# Altium schematic sheet 核心板.SchDoc
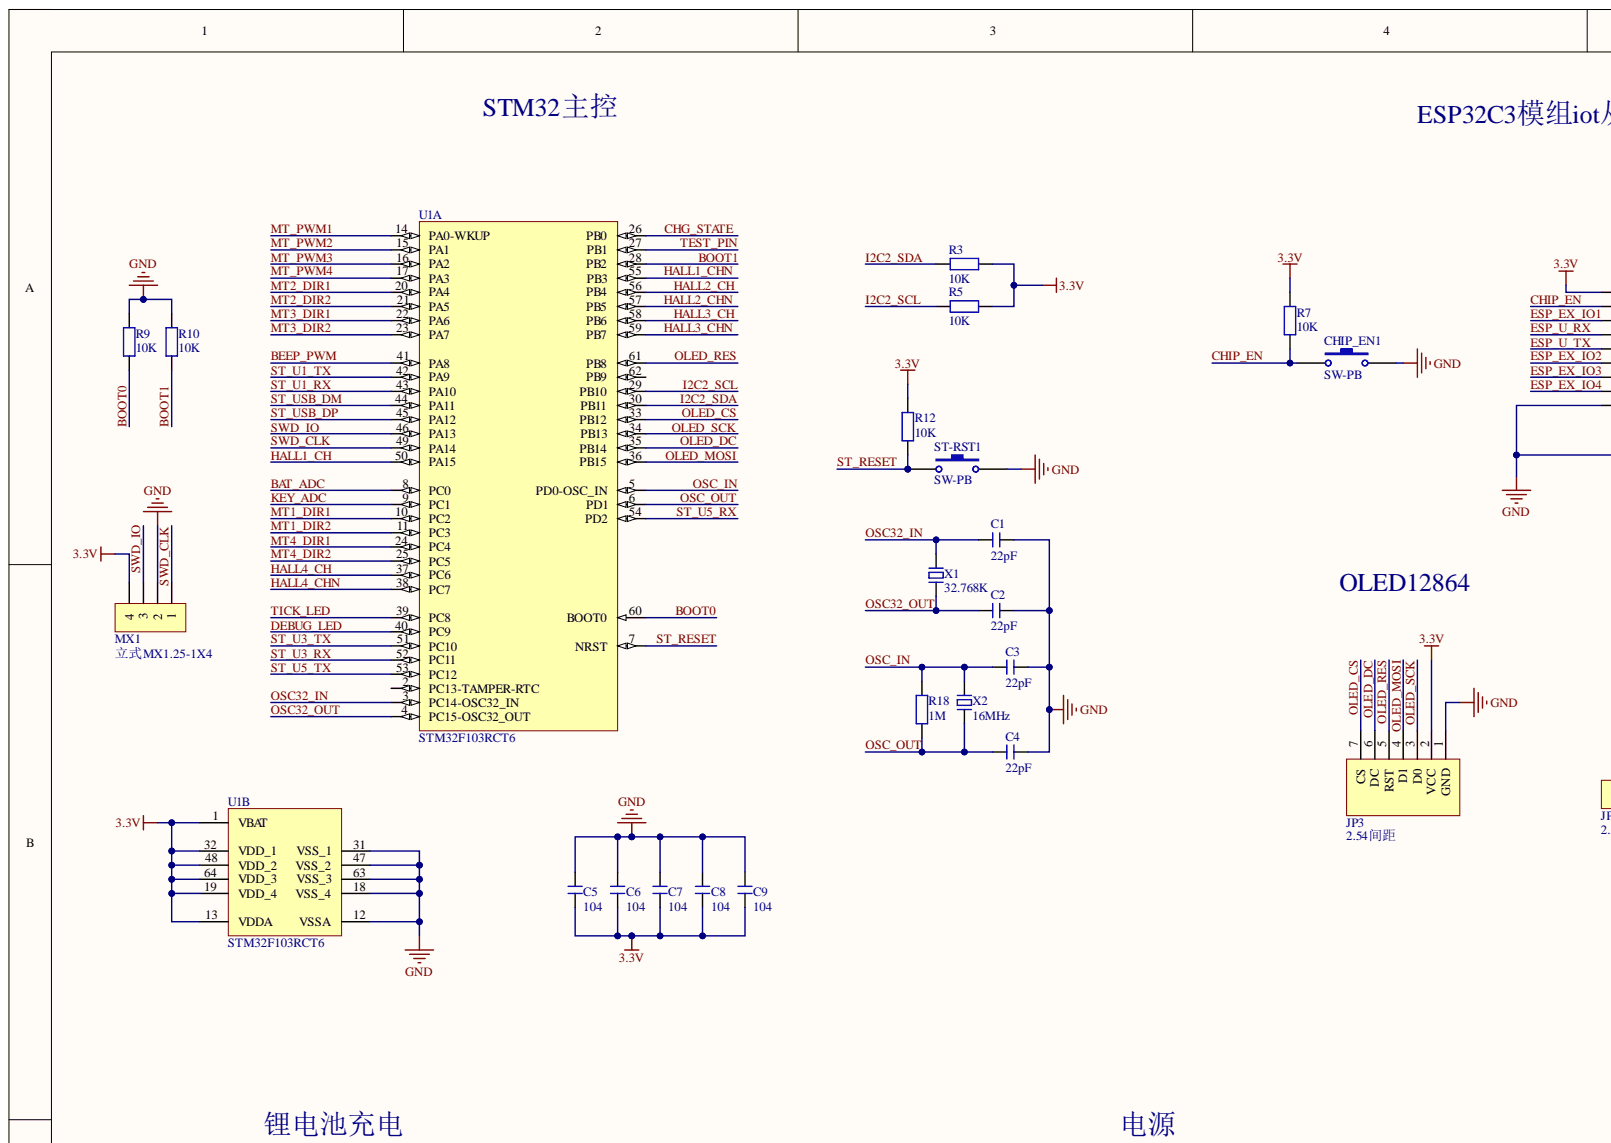
[diagram p0: 核心板.SchDoc, top left]
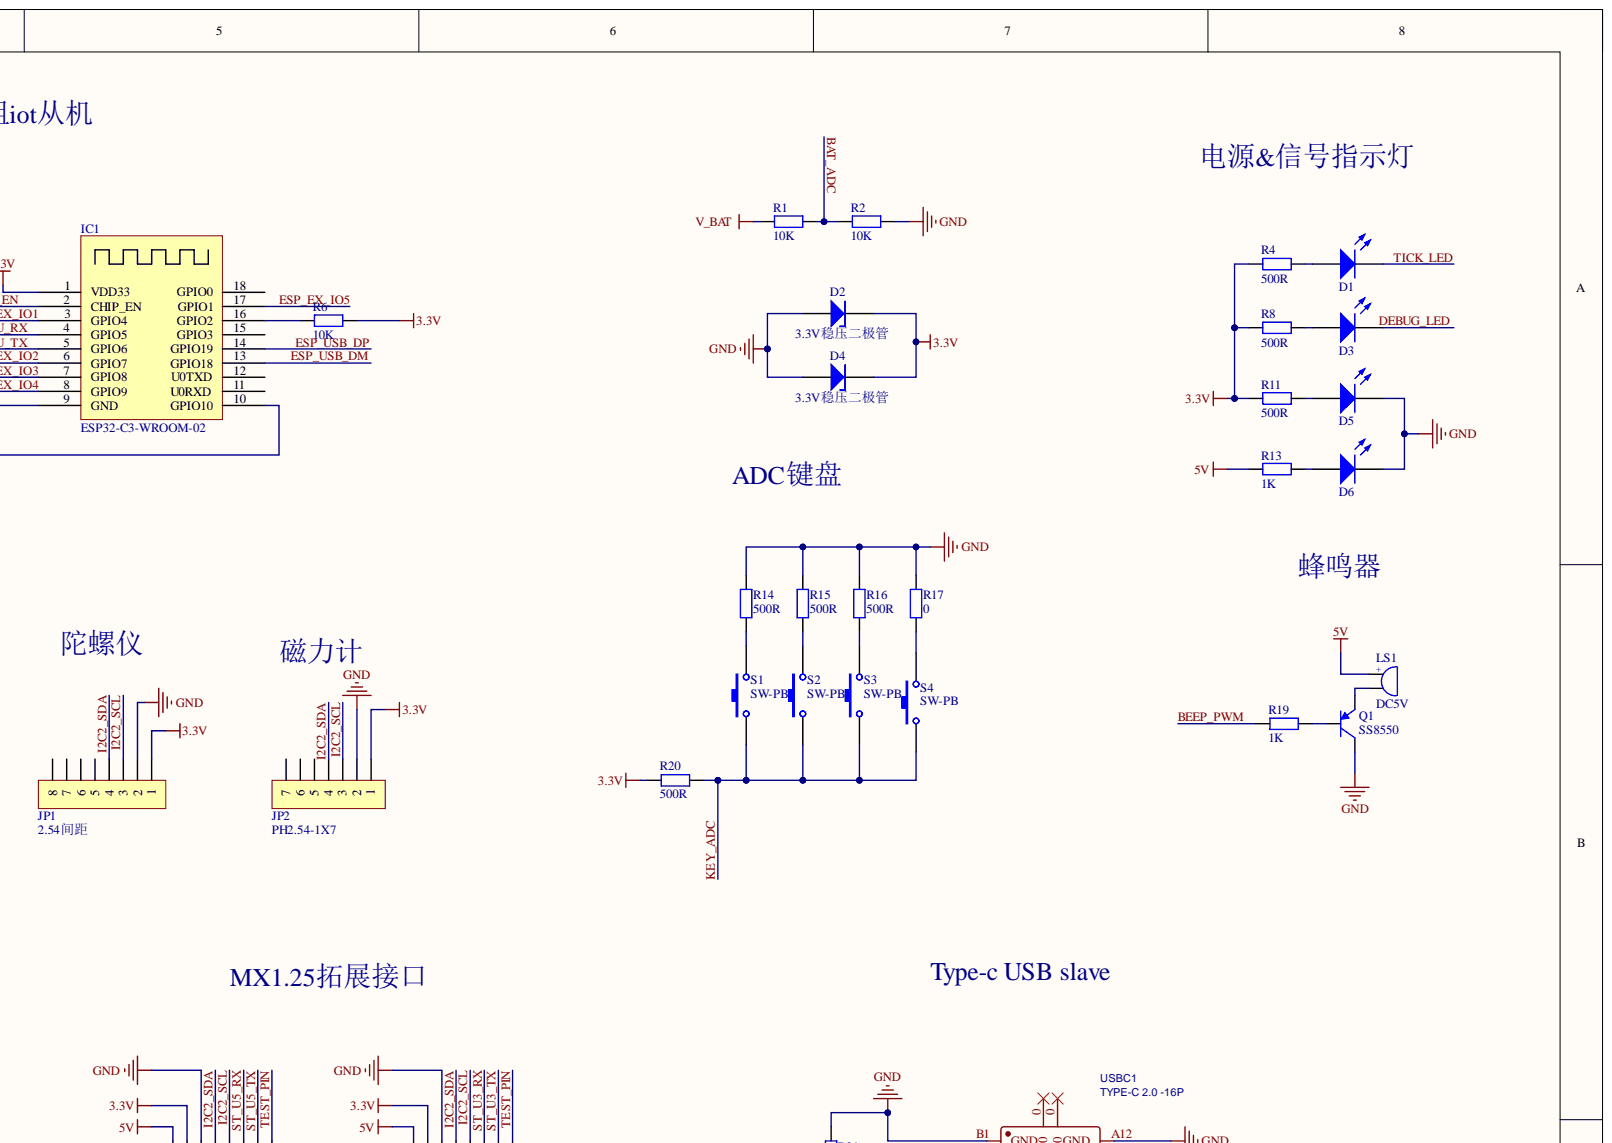
[diagram p1: 核心板.SchDoc, top right]
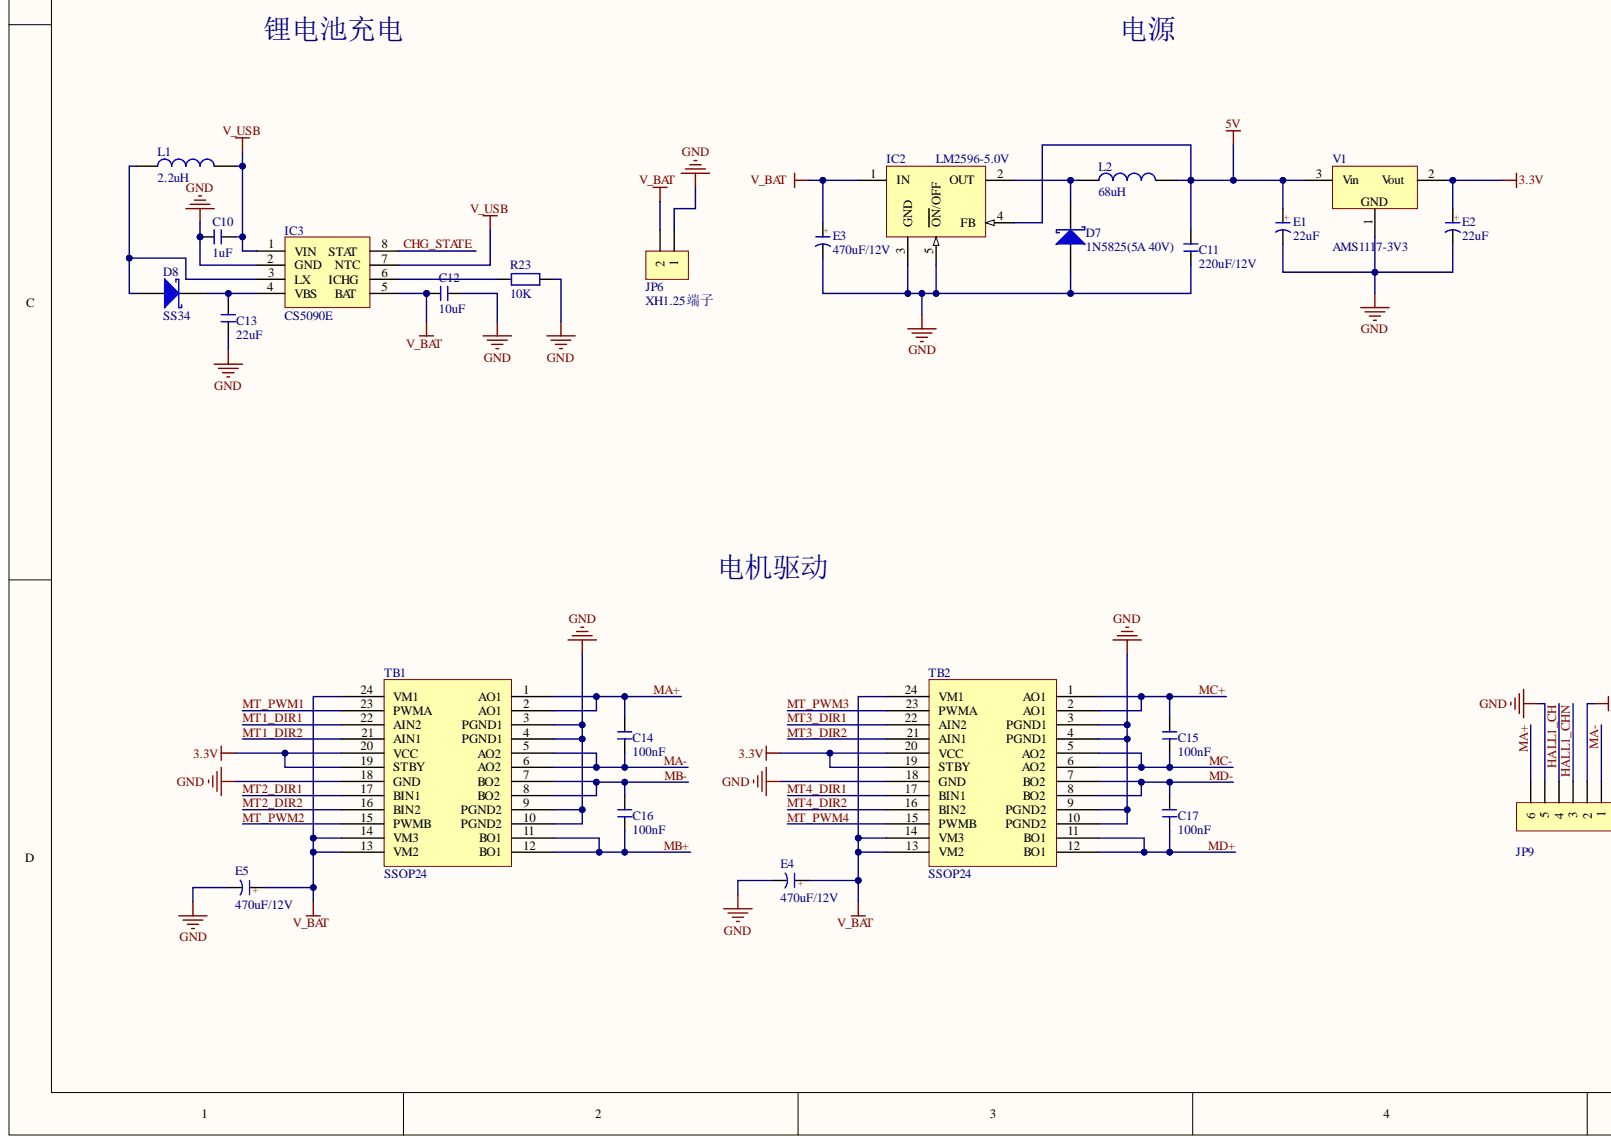
[diagram p2: 核心板.SchDoc, bottom left]
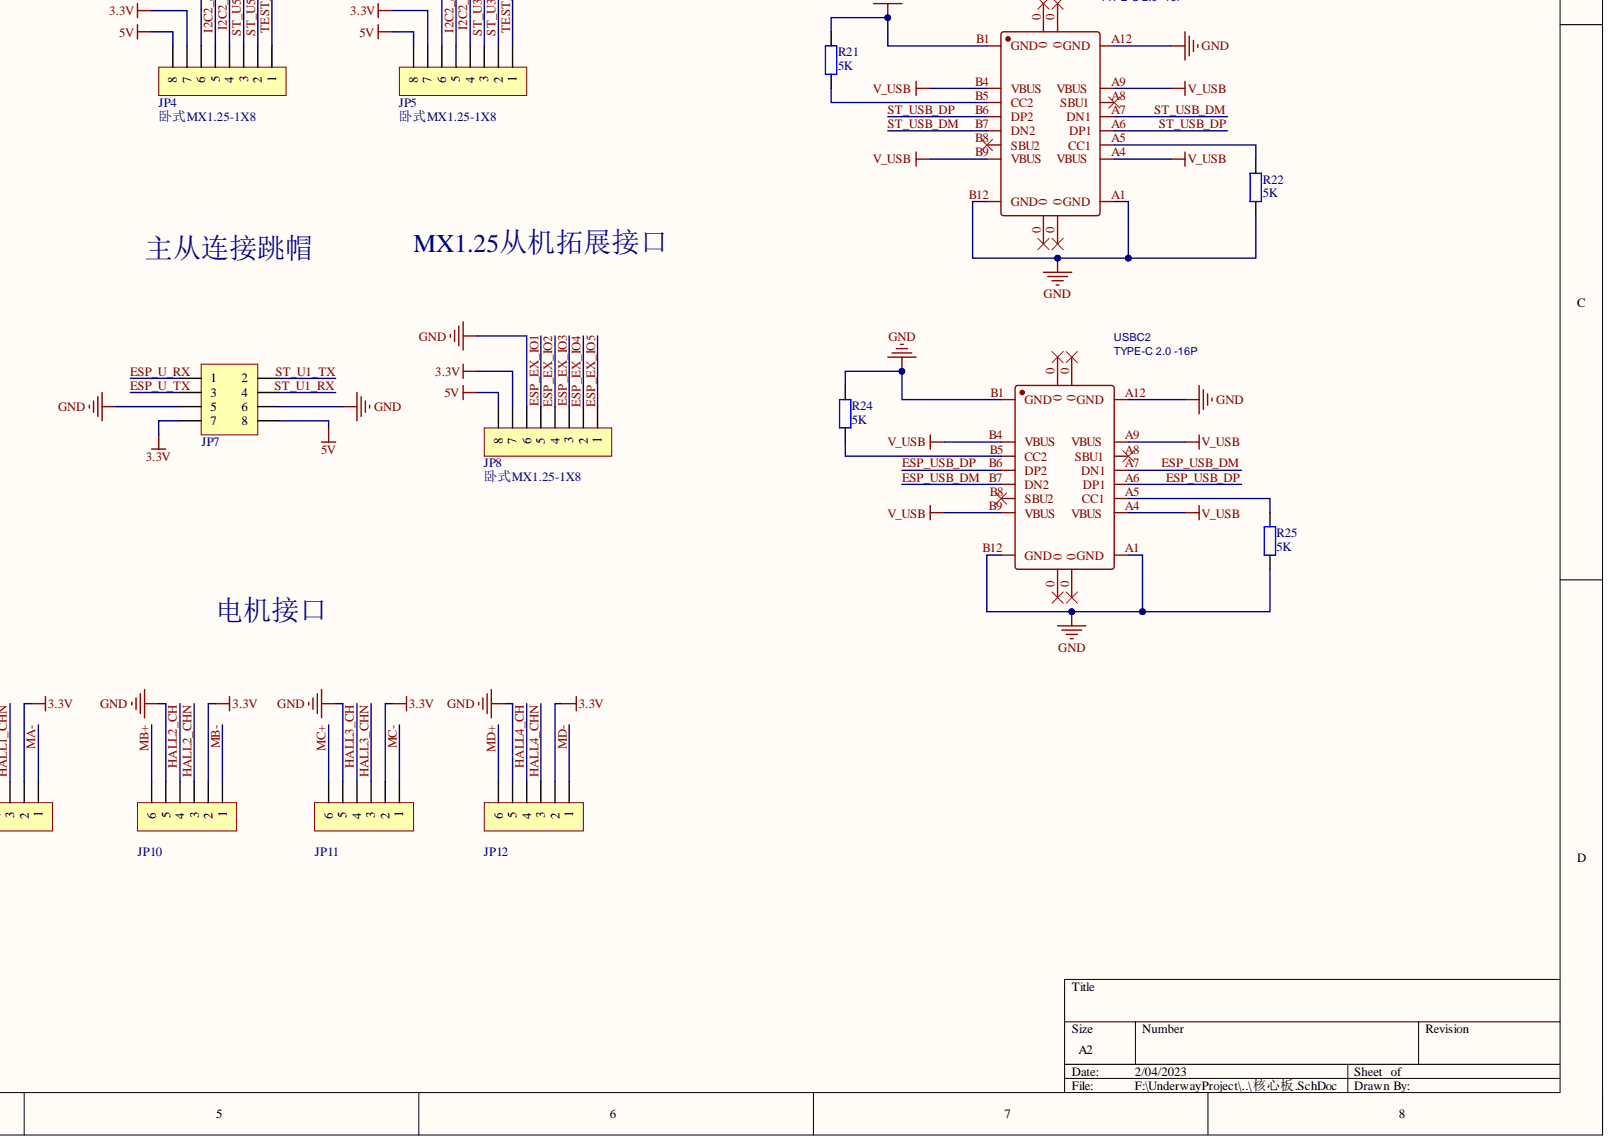
[diagram p3: 核心板.SchDoc, bottom right]

## Parts
C1  22pF  [C 0805_L]
C2  22pF  [C 0805_L]
C3  22pF  [C 0805_L]
C4  22pF  [C 0805_L]
C5  104  [C 0805_L]
C6  104  [C 0805_L]
C7  104  [C 0805_L]
C8  104  [C 0805_L]
C9  104  [C 0805_L]
C10  1uF  [C 0805_L]
C11  220uF/12V  [C 0805_L]
C12  10uF  [C 0805_L]
C13  22uF  [C 0805_L]
C14  100nF  [C 0805_L]
C15  100nF  [C 0805_L]
C16  100nF  [C 0805_L]
C17  100nF  [C 0805_L]
CHIP_EN1  SW-PB  [SW_PB2_A1]
D1  LED  [LED_A1]  (value not drawn)
D2  3.3V稳压二极管  [DIODE-1206]
D3  LED  [LED_A1]  (value not drawn)
D4  3.3V稳压二极管  [DIODE-1206]
D5  LED  [LED_A1]  (value not drawn)
D6  LED  [LED_A1]  (value not drawn)
D7  1N5825(5A 40V)  [SOD-323]
D8  SS34  [SMC]
E1  22uF  [CT 5*6 - C]
E2  22uF  [CT 5*6 - C]
E3  470uF/12V  [CM A(3*5.4)]
E4  470uF/12V  [CM A(3*5.4)]
E5  470uF/12V  [CM A(3*5.4)]
IC1  ESP32-C3-WROOM-02
IC2  LM2596-5.0V  [TO220-5A]
IC3  CS5090E
JP1  2.54间距  [MX 1.25-WI-4P]
JP2  PH2.54-1X7  [MX 1.25-WI-4P]
JP3  2.54间距  [OLED 1.3-12864_7pin]
JP4  卧式MX1.25-1X8  [MX 1.25-WI-4P]
JP5  卧式MX1.25-1X8  [MX 1.25-WI-4P]
JP6  XH1.25端子
JP7  Header 4X2  [IDC2.54-LI-2x4P]  (value not drawn)
JP8  卧式MX1.25-1X8  [MX 1.25-WI-4P]
JP9  HDR-1X6  [XH2.54-WI-6P]  (value not drawn)
JP10  HDR-1X6  [XH2.54-WI-6P]  (value not drawn)
JP11  HDR-1X6  [XH2.54-WI-6P]  (value not drawn)
JP12  HDR-1X6  [XH2.54-WI-6P]  (value not drawn)
L1  2.2uH  [L 0805]
L2  68uH  [L 0805]
LS1  DC5V  [BEEP 5x9x5.5]
MX1  立式MX1.25-1X4  [MX 1.25-WI-4P]
Q1  SS8550  [SOT23-3N]
R1  10K  [R 0805_L]
R2  10K  [R 0805_L]
R3  10K  [R 0805_L]
R4  500R  [R 0805_L]
R5  10K  [R 0805_L]
R6  10K  [R 0805_L]
R7  10K  [R 0805_L]
R8  500R  [R 0805_L]
R9  10K  [R 0805_L]
R10  10K  [R 0805_L]
R11  500R  [R 0805_L]
R12  10K  [R 0805_L]
R13  1K  [R 0805_L]
R14  500R  [R 0805_L]
R15  500R  [R 0805_L]
R16  500R  [R 0805_L]
R17  0  [R 0805_L]
R18  1M  [R 0805_L]
R19  1K  [R 0805_L]
R20  500R  [R 0805_L]
R21  5K  [R 0805_L]
R22  5K  [R 0805_L]
R23  10K  [R 0805_L]
R24  5K  [R 0805_L]
R25  5K  [R 0805_L]
S1  SW-PB  [SW_PB2_A1]
S2  SW-PB  [SW_PB2_A1]
S3  SW-PB  [SW_PB2_A1]
S4  SW-PB  [SW_PB2_A1]
ST-RST1  SW-PB  [SW_PB2_A1]
TB1  SSOP24
TB2  SSOP24
U1  STM32F103RCT6  [LQFP64_N]  (parts A,B)
USBC1  TYPE-C 2.0 -16P  [USB-C-SMD_TYPE-C-USB-5]
USBC2  TYPE-C 2.0 -16P  [USB-C-SMD_TYPE-C-USB-5]
V1  AMS1117-3V3  [SOT223_L]
X1  32.768K  [OSC HC-49S]
X2  16MHz  [OSC HC-49S]

## Nets
3.3V: C5.2 C6.2 C7.2 C8.2 C9.2 D2.2 D4.2 E2.1 IC1.1(VDD33) JP1.1 JP2.1 JP3.2(VCC) JP4.3 JP5.3 JP7.7 JP8.3 JP9.2 JP10.2 JP11.2 JP12.2 MX1.4 R3.2 R4.2 R5.2 R6.2 R7.1 R8.2 R11.2 R12.1 R20.1 TB1.19(STBY) TB1.20(VCC) TB2.19(STBY) TB2.20(VCC) U1.1(VBAT) U1.13(VDDA) U1.19(VDD_4) U1.32(VDD_1) U1.48(VDD_2) U1.64(VDD_3) V1.2
5V: C11.1 E1.1 IC2.4(FB) JP4.4 JP5.4 JP7.8 JP8.4 L2.2 LS1.1 R13.2 V1.3
GND: C1.1 C2.1 C3.1 C4.1 C5.1 C6.1 C7.1 C8.1 C9.1 C10.1 C11.2 C12.2 C13.2 CHIP_EN1.2 D2.1 D4.1 D5.2 D6.2 D7.1 E1.2 E2.2 E3.2 E4.2 E5.2 IC1.9(GND) IC1.10(GPIO10) IC2.3(GND) IC2.5(ON/OFF) IC3.2(GND) JP1.2 JP2.2 JP3.1(GND) JP4.2 JP5.2 JP6.1 JP7.5 JP7.6 JP8.2 JP9.5 JP10.5 JP11.5 JP12.5 MX1.2 Q1.3 R2.1 R9.1 R10.1 R14.2 R15.2 R16.2 R17.2 R21.2 R22.1 R23.1 R24.2 R25.1 ST-RST1.2 TB1.3(PGND1) TB1.4(PGND1) TB1.9(PGND2) TB1.10(PGND2) TB1.18(GND) TB2.3(PGND1) TB2.4(PGND1) TB2.9(PGND2) TB2.10(PGND2) TB2.18(GND) U1.12(VSSA) U1.18(VSS_4) U1.31(VSS_1) U1.47(VSS_2) U1.63(VSS_3) USBC1.A1(GND) USBC1.A12(GND) USBC1.B1(GND) USBC1.B12(GND) USBC2.A1(GND) USBC2.A12(GND) USBC2.B1(GND) USBC2.B12(GND) V1.1
V_BAT: C12.1 E3.1 E4.1 E5.1 IC2.1(IN) IC3.5(BAT) JP6.2 R1.2 TB1.13(VM2) TB1.14(VM3) TB1.24(VM1) TB2.13(VM2) TB2.14(VM3) TB2.24(VM1)
V_USB: C10.2 IC3.1(VIN) IC3.7(NTC) L1.2 USBC1.A4(VBUS) USBC1.A9(VBUS) USBC1.B4(VBUS) USBC1.B9(VBUS) USBC2.A4(VBUS) USBC2.A9(VBUS) USBC2.B4(VBUS) USBC2.B9(VBUS)
BAT_ADC: R1.1 R2.2 U1.8(PC0)
BEEP_PWM: R19.2 U1.41(PA8)
BOOT0: R9.2 U1.60(BOOT0)
BOOT1: R10.2 U1.28(PB2)
CHG_STATE: IC3.8(STAT) U1.26(PB0)
CHIP_EN: CHIP_EN1.1 IC1.2(CHIP_EN) R7.2
DEBUG_LED: D3.2 U1.40(PC9)
ESP_EX_IO1: IC1.3(GPIO4) JP8.1
ESP_EX_IO2: IC1.6(GPIO7) JP8.4
ESP_EX_IO3: IC1.7(GPIO8) JP8.3
ESP_EX_IO4: IC1.8(GPIO9) JP8.2
ESP_EX_IO5: IC1.17(GPIO1) JP8.1
ESP_U_RX: IC1.4(GPIO5) JP7.1
ESP_U_TX: IC1.5(GPIO6) JP7.3
ESP_USB_DM: IC1.13(GPIO18) USBC2.A7(DN1) USBC2.B7(DN2)
ESP_USB_DP: IC1.14(GPIO19) USBC2.A6(DP1) USBC2.B6(DP2)
HALL1_CH: JP9.4 U1.50(PA15)
HALL1_CHN: JP9.3 U1.55(PB3)
HALL2_CH: JP10.4 U1.56(PB4)
HALL2_CHN: JP10.3 U1.57(PB5)
HALL3_CH: JP11.4 U1.58(PB6)
HALL3_CHN: JP11.3 U1.59(PB7)
HALL4_CH: JP12.4 U1.37(PC6)
HALL4_CHN: JP12.3 U1.38(PC7)
I2C2_SCL: JP1.3 JP2.3 JP4.4 JP5.4 R5.1 U1.29(PB10)
I2C2_SDA: JP1.4 JP2.4 JP4.1 JP5.1 R3.1 U1.30(PB11)
KEY_ADC: R20.2 S1.1 S2.1 S3.1 S4.1 U1.9(PC1)
MA+: C14.1 JP9.6 TB1.1(AO1) TB1.2(AO1)
MA-: C14.2 JP9.1 TB1.5(AO2) TB1.6(AO2)
MB+: C16.2 JP10.6 TB1.11(BO1) TB1.12(BO1)
MB-: C16.1 JP10.1 TB1.7(BO2) TB1.8(BO2)
MC+: C15.1 JP11.6 TB2.1(AO1) TB2.2(AO1)
MC-: C15.2 JP11.1 TB2.5(AO2) TB2.6(AO2)
MD+: C17.2 JP12.6 TB2.11(BO1) TB2.12(BO1)
MD-: C17.1 JP12.1 TB2.7(BO2) TB2.8(BO2)
MT1_DIR1: TB1.22(AIN2) U1.10(PC2)
MT1_DIR2: TB1.21(AIN1) U1.11(PC3)
MT2_DIR1: TB1.17(BIN1) U1.20(PA4)
MT2_DIR2: TB1.16(BIN2) U1.21(PA5)
MT3_DIR1: TB2.22(AIN2) U1.22(PA6)
MT3_DIR2: TB2.21(AIN1) U1.23(PA7)
MT4_DIR1: TB2.17(BIN1) U1.24(PC4)
MT4_DIR2: TB2.16(BIN2) U1.25(PC5)
MT_PWM1: TB1.23(PWMA) U1.14(PA0-WKUP)
MT_PWM2: TB1.15(PWMB) U1.15(PA1)
MT_PWM3: TB2.23(PWMA) U1.16(PA2)
MT_PWM4: TB2.15(PWMB) U1.17(PA3)
OLED_CS: JP3.7(CS) U1.33(PB12)
OLED_DC: JP3.6(DC) U1.35(PB14)
OLED_MOSI: JP3.4(D1) U1.36(PB15)
OLED_RES: JP3.5(RST) U1.61(PB8)
OLED_SCK: JP3.3 U1.34(PB13)
OSC32_IN: C1.2 U1.3(PC14-OSC32_IN) X1.1
OSC32_OUT: C2.2 U1.4(PC15-OSC32_OUT) X1.2
OSC_IN: C3.2 R18.2 U1.5(PD0-OSC_IN) X2.1
OSC_OUT: C4.2 R18.1 U1.6(PD1) X2.2
ST_RESET: R12.2 ST-RST1.1 U1.7(NRST)
ST_U1_RX: JP7.4 U1.43(PA10)
ST_U1_TX: JP7.2 U1.42(PA9)
ST_U3_RX: JP5.3 U1.52(PC11)
ST_U3_TX: JP5.2 U1.51(PC10)
ST_U5_RX: JP4.3 U1.54(PD2)
ST_U5_TX: JP4.2 U1.53(PC12)
ST_USB_DM: U1.44(PA11) USBC1.A7(DN1) USBC1.B7(DN2)
ST_USB_DP: U1.45(PA12) USBC1.A6(DP1) USBC1.B6(DP2)
SWD_CLK: MX1.1 U1.49(PA14)
SWD_IO: MX1.3 U1.46(PA13)
TEST_PIN: JP4.1 JP5.1 U1.27(PB1)
TICK_LED: D1.2 U1.39(PC8)
NetC13_1: C13.1 D8.2 IC3.4(VBS)
NetD1_1: D1.1 R4.1
NetD3_1: D3.1 R8.1
NetD5_1: D5.1 R11.1
NetD6_1: D6.1 R13.1
NetD7_2: D7.2 IC2.2(OUT) L2.1
NetD8_1: D8.1 IC3.3(LX) L1.1
NetIC1_16: IC1.16(GPIO2) R6.1
NetIC3_6: IC3.6(ICHG) R23.2
NetLS1_2: LS1.2 Q1.2
NetQ1_1: Q1.1 R19.1
NetR14_1: R14.1 S1.2
NetR15_1: R15.1 S2.2
NetR16_1: R16.1 S3.2
NetR17_1: R17.1 S4.2
NetR21_1: R21.1 USBC1.B5(CC2)
NetR22_2: R22.2 USBC1.A5(CC1)
NetR24_1: R24.1 USBC2.B5(CC2)
NetR25_2: R25.2 USBC2.A5(CC1)

Not connected: IC1 11(U0RXD) 12(U0TXD) 15(GPIO3) 18(GPIO0); U1 2(PC13-TAMPER-RTC) 62(PB9); USBC1 0 A8(SBU1) B8(SBU2); USBC2 0 A8(SBU1) B8(SBU2)

## Library data of the parts
C1-C17 = C: 贴片电容; BOM名称: 无极性贴片电容; 淘宝ID: 封装库来自淘宝 ID：黄晶宝石; Component Kind: Standard; Library Name: 核心板1.SchLib; 唯一出售店铺网址: https://shop463601444.taobao.com; 联系售后，技术支持: https://shop463601444.taobao.com
CHIP_EN1 S1-S4 ST-RST1 = SW-PB: 微动开关; Component Kind: Standard; LatestRevisionDate: 17-Jul-2002; LatestRevisionNote: Re-released for DXP Platform.; Library Name: 核心板1.SchLib; PackageReference: SPST-2; Published: 24-Mar-1999; Publisher: Altium Limited
D1 D3 D5 D6 = LED: 发光二极管; Component Kind: Standard; Library Name: 核心板1.SchLib; 技术支持，联系售后: https://shop463601444.taobao.com
D2 D4 = 稳压二极管: Zener Diode; 封装库来自淘宝卖家 ID：黄晶宝石: 其他店铺购入均为盗版，不享受售后技术支持服务，请立即申请退款; Component Kind: Standard; Library Name: 核心板1.SchLib
D7 = 1N5819: 肖特基二极管; 属性: 40V/2A; 淘宝ID: 封装库来自淘宝 ID：黄晶宝石; BOM名称: 肖特基二极管; Component Kind: Standard; Library Name: 核心板1.SchLib; 欢迎光临: https://shop463601444.taobao.com
D8 = SS34: 肖特基二极管; 属性: 40V/3A; BOM名称: 肖特基二极管; Component Kind: Standard; Library Name: 核心板1.SchLib; 封装库来自淘宝 ID：黄晶宝石: https://shop463601444.taobao.com
E1 E2 = CS_SMD: 贴片固态电容; 版权归属: 封装库来自淘宝 ID：黄晶宝石; BOM名称: 贴片固态电容; Component Kind: Standard; Library Name: 0.1 - 电阻-电容-电感.SchLib; 联系售后: https://shop463601444.taobao.com
E3-E5 = C-CM: 贴片电解电容; 版权归属: 封装库来自淘宝 ID：黄晶宝石; BOM名称: 贴片电解电容; Component Kind: Standard; 联系售后: https://shop463601444.taobao.com
IC1 = ESP32-C3-WROOM-02: ESP32C3模组; Component Kind: Standard; Library Name: 核心板1.SchLib
IC2 = LM2596-5.0: DC降压芯片; BOM名称: DC稳压芯片; 版权归属: 封装库来自淘宝 ID：黄晶宝石; Component Kind: Standard; Library Name: 核心板1.SchLib; 学习资源: https://shop463601444.taobao.com
IC3 = CS5090E: 8.4V锂电池充电芯片; Component Kind: Standard; Library Name: 核心板1.SchLib
JP1 JP4 JP5 JP8 = Header 8: 8P接插件; BOM名称: 4P接插件; 联系售后: 封装库来自淘宝 ID：黄晶宝石; Component Kind: Standard; Library Name: 核心板1.SchLib; 学习资源: https://shop463601444.taobao.com
JP2 = Header 7: 7P接插件 - SPI ssd1306; BOM名称: 4P接插件; 联系售后: 封装库来自淘宝 ID：黄晶宝石; Component Kind: Standard; Library Name: 核心板1.SchLib; 学习资源: https://shop463601444.taobao.com
JP3 = OLED 1.3-12864_7pin: 12864 点阵屏; 版权归属: 封装库来自淘宝 ID：黄晶宝石; BOM名称: 12864 点阵屏; Component Kind: Standard; Library Name: 核心板1.SchLib; 技术支持，联系售后: https://shop463601444.taobao.com
JP6 = Header 2: Component Kind: Standard; Library Name: 核心板1.SchLib
JP7 = Header 4X2: 4*2P接插件; 版权归属: 封装库来自淘宝 ID：黄晶宝石; BOM名称: 4*2P接插件; Component Kind: Standard; Library Name: 核心板1.SchLib; 学习资源: https://shop463601444.taobao.com
JP9-JP12 = Header 6: 6P接插件; 版权归属: 封装库来自淘宝 ID：黄晶宝石; BOM名称: 6P接插件; Component Kind: Standard; Library Name: 核心板1.SchLib; 学习资源: https://shop463601444.taobao.com
L1 L2 = L: 小功率贴片电感; 封装库来自淘宝卖家 ID：黄晶宝石: 其他店铺购入均为盗版，不享受售后技术支持服务，请立即申请退款; 淘宝ID: 封装库来自淘宝 ID：黄晶宝石; BOM名称: 小功率贴片电感; Component Kind: Standard; Library Name: 核心板1.SchLib; 联系售后: https://shop463601444.taobao.com
LS1 = BEEP: 蜂鸣器; 版权归属: 封装库来自淘宝 ID：黄晶宝石; BOM名称: 蜂鸣器; Component Kind: Standard; Library Name: 核心板1.SchLib; 技术支持，联系售后: https://shop463601444.taobao.com
MX1 = Header 4: 4P接插件; 联系售后: 封装库来自淘宝 ID：黄晶宝石; BOM名称: 4P接插件; Component Kind: Standard; Library Name: 核心板1.SchLib; 学习资源: https://shop463601444.taobao.com
Q1 = 8550-SMD: 高频放大-PNP型; 属性: 40V 1.5A 1W 100MHZ; 版权归属: 封装库来自淘宝 ID：黄晶宝石; BOM名称: 高频放大-PNP型; Component Kind: Standard; 学习资源: www.ooocke.com
R1-R25 = R: 贴片电阻; 封装库来自淘宝卖家 ID：黄晶宝石: 其他店铺购入均为盗版，不享受售后技术支持服务，请立即申请退款; 版权归属: 来自淘宝 ID：黄晶宝石; BOM名称: 贴片电阻; Component Kind: Standard; Library Name: 核心板1.SchLib; 联系售后: https://shop463601444.taobao.com
TB1 TB2 = TB6612FNG: 电机驱动IC; Component Kind: Standard; Library Name: 核心板1.SchLib
U1 = STM32F103RCT6: STM32 ARM-based 32-bit MCU Performance Line with 256 kB Flash, 48 kB Internal RAM, -40 to +85癈 Temperature, 64-Pin LQFP, Tray; : https://shop463601444.taobao.com; Component Kind: Standard; ComponentLink1Description: Manufacturer URL; ComponentLink1URL: http://www.st.com/; ComponentLink2Description: STM32F103 Datasheet; ComponentLink2URL: http://www.st.com/internet/com/TECHNICAL_RESOURCES/TECHNICAL_LITERATURE/DATASHEET/CD00191185.pdf; ComponentLink3Description: STM32 Family Web Page; ComponentLink3URL: www.st.com/stm32; DatasheetVersion: rev8.0, Apr-2011; Library Name: 核心板1.SchLib; PackageDescription: 64-Pin Low-Profile Quad Flat Pack, 10 x 10 mm Body, 0.5 mm Pitch (LQFP64); PackageReference: LQFP64; PackageVersion: rev13, Apr-2011
USBC1 USBC2 = USB2.0 Type-C 16Pin母座: USB2.0 Type-C 母座 16Pin; BOM_Manufacturer: LCSC; BOM_Manufacturer Part: -; BOM_Supplier: LCSC; Component Kind: Standard; Library Name: 核心板1.SchLib; spicePre: U; spiceSymbolName: TYPE-C 3.1 -16P_C168689
V1 = AMS1117: 三端稳压芯片; BOM名称: 稳压芯片; 淘宝ID: 封装库来自淘宝 ID：黄晶宝石; Component Kind: Standard; Library Name: 核心板1.SchLib; 欢迎光临淘宝店铺: https://shop463601444.taobao.com
X1 X2 = XTAL-2P: 2脚无源脚晶振; 版权归属: 封装库来自淘宝卖家 ID：黄晶宝石; BOM名称: 2脚无源脚晶振; Component Kind: Standard; Library Name: Schlib1.SchLib; 学习资源: https://shop463601444.taobao.com
